# Altium schematic sheet gate_driving_master.SchDoc(gate_driving_master)
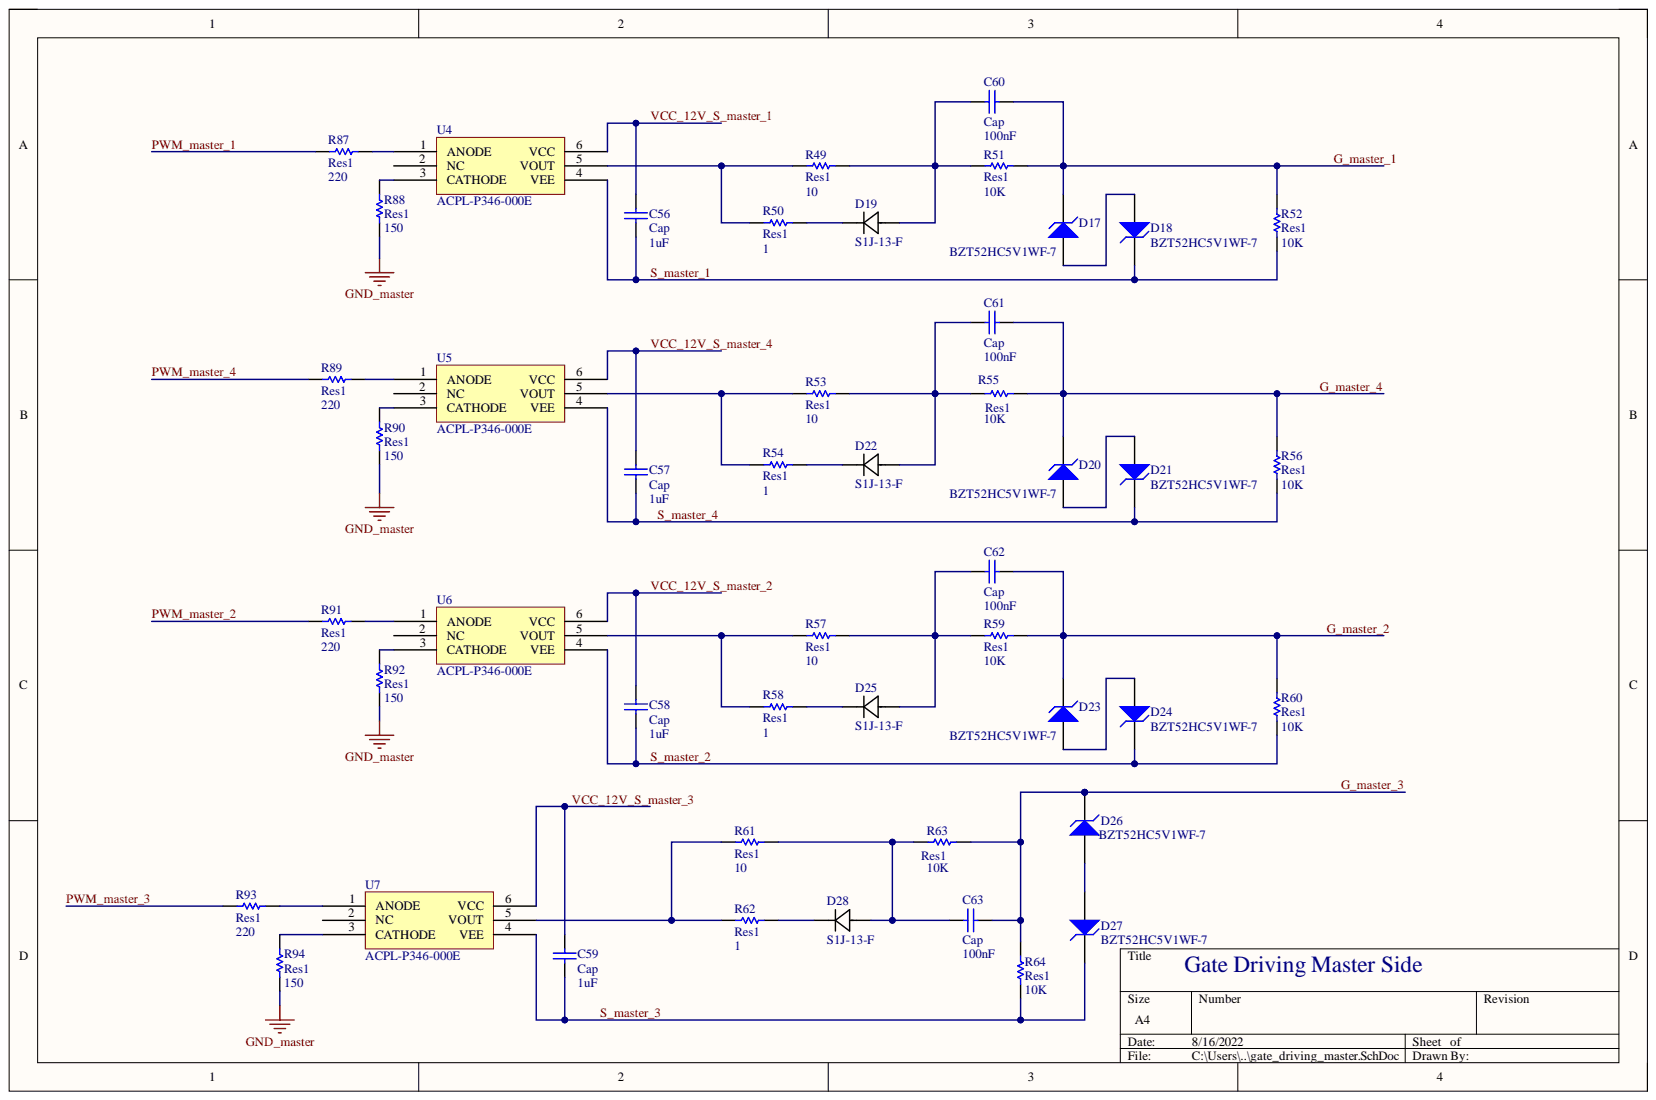
[diagram p0: gate_driving_master.SchDoc]

## Parts
C56  Cap  value 1uF  [C0805]
C57  Cap  value 1uF  [C0805]
C58  Cap  value 1uF  [C0805]
C59  Cap  value 1uF  [C0805]
C60  Cap  value 100nF  [C0805]
C61  Cap  value 100nF  [C0805]
C62  Cap  value 100nF  [C0805]
C63  Cap  value 100nF  [C0805]
D17  BZT52HC5V1WF-7  [SOD123F (Type B)]
D18  BZT52HC5V1WF-7  [SOD123F (Type B)]
D19  S1J-13-F  [SMA_DIO]
D20  BZT52HC5V1WF-7  [SOD123F (Type B)]
D21  BZT52HC5V1WF-7  [SOD123F (Type B)]
D22  S1J-13-F  [SMA_DIO]
D23  BZT52HC5V1WF-7  [SOD123F (Type B)]
D24  BZT52HC5V1WF-7  [SOD123F (Type B)]
D25  S1J-13-F  [SMA_DIO]
D26  BZT52HC5V1WF-7  [SOD123F (Type B)]
D27  BZT52HC5V1WF-7  [SOD123F (Type B)]
D28  S1J-13-F  [SMA_DIO]
R49  Res1  value 10  [RES0805W]
R50  Res1  value 1  [RES0805W]
R51  Res1  value 10K  [RES0805W]
R52  Res1  value 10K  [RES0805W]
R53  Res1  value 10  [RES0805W]
R54  Res1  value 1  [RES0805W]
R55  Res1  value 10K  [RES0805W]
R56  Res1  value 10K  [RES0805W]
R57  Res1  value 10  [RES0805W]
R58  Res1  value 1  [RES0805W]
R59  Res1  value 10K  [RES0805W]
R60  Res1  value 10K  [RES0805W]
R61  Res1  value 10  [RES0805W]
R62  Res1  value 1  [RES0805W]
R63  Res1  value 10K  [RES0805W]
R64  Res1  value 10K  [RES0805W]
R87  Res1  value 220  [RES0805W]
R88  Res1  value 150  [RES0805W]
R89  Res1  value 220  [RES0805W]
R90  Res1  value 150  [RES0805W]
R91  Res1  value 220  [RES0805W]
R92  Res1  value 150  [RES0805W]
R93  Res1  value 220  [RES0805W]
R94  Res1  value 150  [RES0805W]
U4  ACPL-P346-000E  [ACPL-P346-000E]
U5  ACPL-P346-000E  [ACPL-P346-000E]
U6  ACPL-P346-000E  [ACPL-P346-000E]
U7  ACPL-P346-000E  [ACPL-P346-000E]

## Nets
GND_master: R88.1 R90.1 R92.1 R94.1
G_master_1: C60.1 D17.2 R51.2 R52.2
G_master_2: C62.1 D23.2 R59.2 R60.2
G_master_3: C63.1 D26.2 R63.2 R64.2
G_master_4: C61.1 D20.2 R55.2 R56.2
PWM_master_1: R87.1
PWM_master_2: R91.1
PWM_master_3: R93.1
PWM_master_4: R89.1
S_master_1: C56.1 D18.2 R52.1 U4.4(VEE)
S_master_2: C58.1 D24.2 R60.1 U6.4(VEE)
S_master_3: C59.1 D27.2 R64.1 U7.4(VEE)
S_master_4: C57.1 D21.2 R56.1 U5.4(VEE)
VCC_12V_S_master_1: C56.2 U4.6(VCC)
VCC_12V_S_master_2: C58.2 U6.6(VCC)
VCC_12V_S_master_3: C59.2 U7.6(VCC)
VCC_12V_S_master_4: C57.2 U5.6(VCC)
NetC60_2: C60.2 D19.2 R49.2 R51.1
NetC61_2: C61.2 D22.2 R53.2 R55.1
NetC62_2: C62.2 D25.2 R57.2 R59.1
NetC63_2: C63.2 D28.2 R61.2 R63.1
NetD17_1: D17.1 D18.1
NetD19_1: D19.1 R50.2
NetD20_1: D20.1 D21.1
NetD22_1: D22.1 R54.2
NetD23_1: D23.1 D24.1
NetD25_1: D25.1 R58.2
NetD26_1: D26.1 D27.1
NetD28_1: D28.1 R62.2
NetR49_1: R49.1 R50.1 U4.5(VOUT)
NetR53_1: R53.1 R54.1 U5.5(VOUT)
NetR57_1: R57.1 R58.1 U6.5(VOUT)
NetR61_1: R61.1 R62.1 U7.5(VOUT)
NetR87_2: R87.2 U4.1(ANODE)
NetR88_2: R88.2 U4.3(CATHODE)
NetR89_2: R89.2 U5.1(ANODE)
NetR90_2: R90.2 U5.3(CATHODE)
NetR91_2: R91.2 U6.1(ANODE)
NetR92_2: R92.2 U6.3(CATHODE)
NetR93_2: R93.2 U7.1(ANODE)
NetR94_2: R94.2 U7.3(CATHODE)

Not connected: U4 2(NC); U5 2(NC); U6 2(NC); U7 2(NC)

## Library data of the parts
C56-C59 C63 = Cap: Capacitor; Component Kind: Standard; LatestRevisionDate: 17-Jul-2002; LatestRevisionNote: Re-released for DXP Platform.; Library Name: Miscellaneous Devices.IntLib; PackageDescription: Radial Cap, Thru-Hole; 2 Leads; 0.3 in Pin Spacing; PackageReference: RAD-0.3; Published: 8-Jun-2000; Signal Integrity: Cap; Simulation: CAP; Publisher: Altium Limited
C60-C62 = Cap: Capacitor; Value: 100nF; Component Kind: Standard; LatestRevisionDate: 17-Jul-2002; LatestRevisionNote: Re-released for DXP Platform.; Library Name: Miscellaneous Devices.IntLib; PackageDescription: Radial Cap, Thru-Hole; 2 Leads; 0.3 in Pin Spacing; PackageReference: RAD-0.3; Published: 8-Jun-2000; Signal Integrity: Cap; Simulation: CAP; Publisher: Altium Limited
D17 D18 D20 D21 D23 D24 D26 D27 = D Zener: Zener Diode; Component Kind: Standard; LatestRevisionDate: 17-Jul-2002; LatestRevisionNote: Re-released for DXP Platform.; Library Name: Miscellaneous Devices.IntLib; PackageDescription: Diode, Thru-Hole; 2 Leads; 0.7 in Pin Spacing; PackageReference: DIODE-0.7; Published: 8-Jun-2000; Signal Integrity: D Zener; Simulation: ZENER; Publisher: Altium Limited
D19 D22 D25 D28 = S1J-13-F: No Description Available; Mfr_Name: Diodes Inc; Type: DEV; Component Kind: Standard; Digi-Key_Part_Number_1: S1J-FDICT-ND; Digi-Key_Part_Number_2: S1J-FDIDKR-ND; Digi-Key_Part_Number_3: S1J-FDITR-ND; Library Name: S1J-13-F.SchLib; Manufacturer_Part_Number: S1J-13-F; RefDes: RefDes; Copyright: Copyright (C) 2022 Ultra Librarian. All rights reserved.
R49-R64 R87-R94 = Res1: Resistor; Component Kind: Standard; LatestRevisionDate: 17-Jul-2002; LatestRevisionNote: Re-released for DXP Platform.; Library Name: Miscellaneous Devices.IntLib; PackageDescription: Axial Device, Thru-Hole; 2 Leads; 0.3 in Pin Spacing; PackageReference: AXIAL-0.3; Published: 8-Jun-2000; Signal Integrity: Res; Simulation: RESISTOR; Publisher: Altium Limited
U4-U7 = ACPL-P346-000E: Component Kind: Standard; Library Name: ACPL-P346-000E.SchLib
